# Altium schematic sheet Power_Board_DRV8323.SchDoc
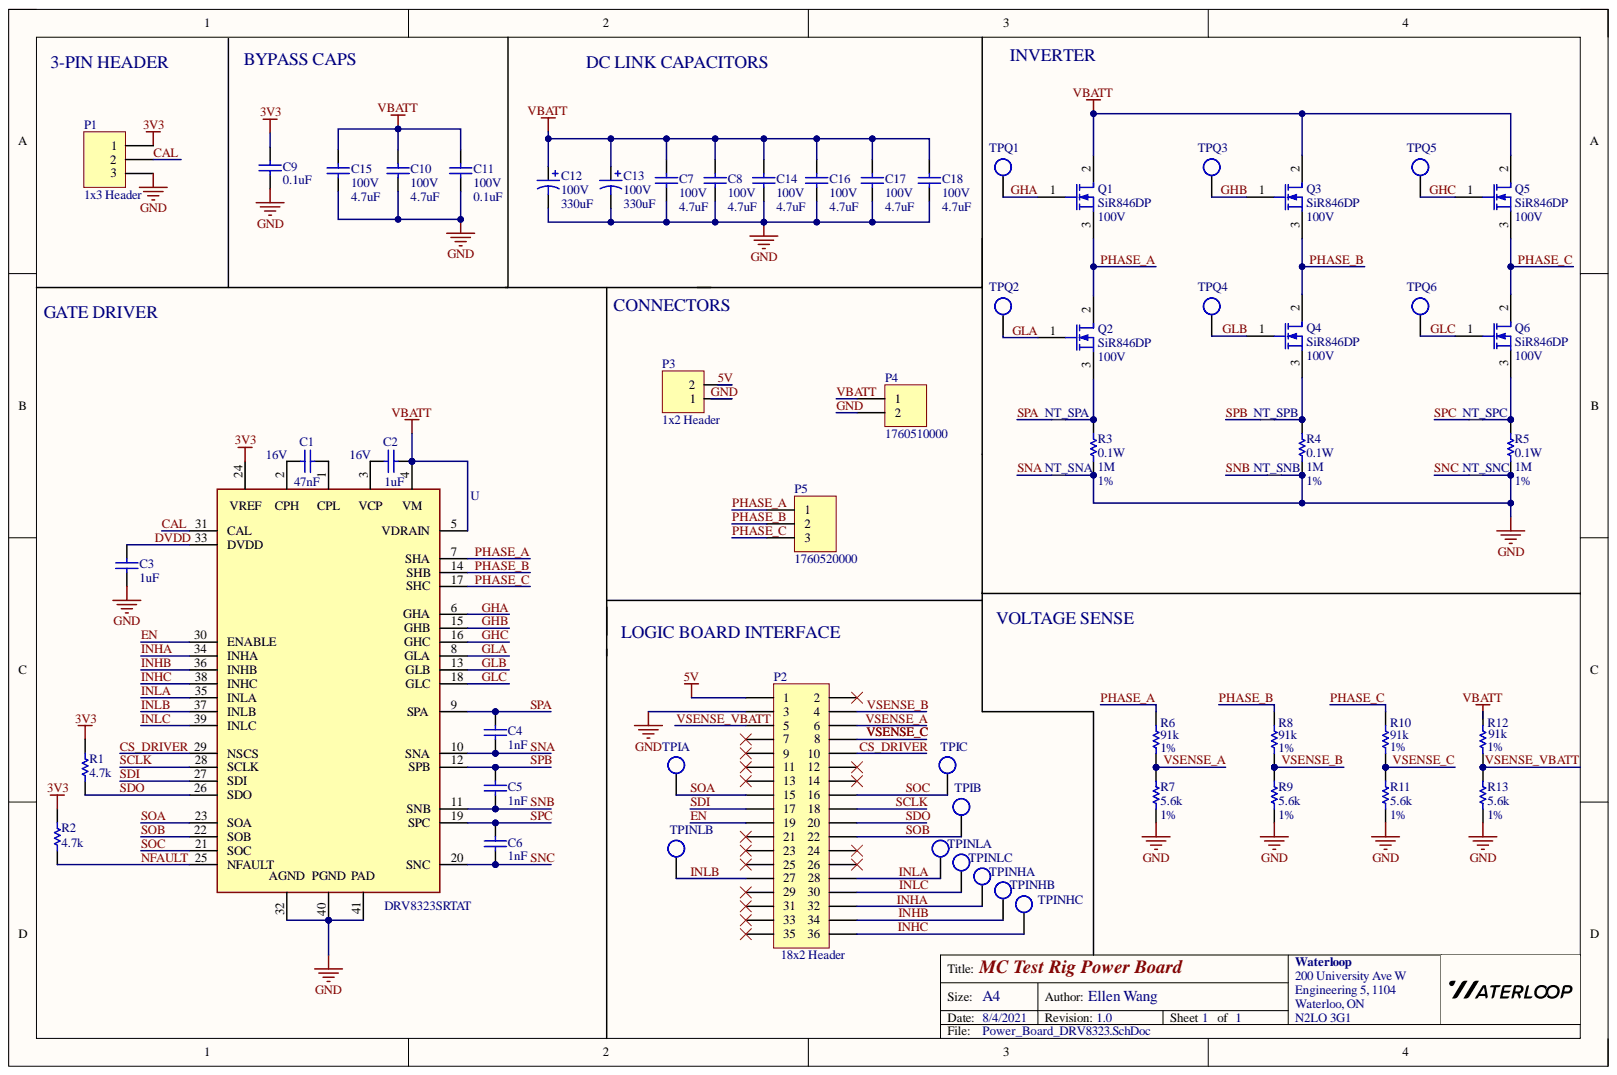
[diagram p0: Power_Board_DRV8323.SchDoc]

## Parts
C1  value 47nF  Rating: 16V  [0805 - Capacitor]  (hidden comment: Capacitor - Nonpolarized)
C2  value 1uF  Rating: 16V  [0805 - Capacitor]  (hidden comment: Capacitor - Nonpolarized)
C3  value 1uF  [0805 - Capacitor]  (hidden comment: Capacitor - Nonpolarized)
C4  value 1nF  [0805 - Capacitor]  (hidden comment: Capacitor - Nonpolarized)
C5  value 1nF  [0805 - Capacitor]  (hidden comment: Capacitor - Nonpolarized)
C6  value 1nF  [0805 - Capacitor]  (hidden comment: Capacitor - Nonpolarized)
C7  value 4.7uF  Rating: 100V  [1210 - Capacitor]  (hidden comment: Capacitor - Nonpolarized)
C8  value 4.7uF  Rating: 100V  [1210 - Capacitor]  (hidden comment: Capacitor - Nonpolarized)
C9  value 0.1uF  [0805 - Capacitor]  (hidden comment: Capacitor - Nonpolarized)
C10  value 4.7uF  Rating: 100V  [1210 - Capacitor]  (hidden comment: Capacitor - Nonpolarized)
C11  value 0.1uF  Rating: 100V  [0805 - Capacitor]  (hidden comment: Capacitor - Nonpolarized)
C12  value 330uF  Rating: 100V  [OCVZ0606 - CAPACITOR]  (hidden comment: Capacitor - Polarized)
C13  value 330uF  Rating: 100V  [OCVZ0606 - CAPACITOR]  (hidden comment: Capacitor - Polarized)
C14  value 4.7uF  Rating: 100V  [1210 - Capacitor]  (hidden comment: Capacitor - Nonpolarized)
C15  value 4.7uF  Rating: 100V  [1210 - Capacitor]  (hidden comment: Capacitor - Nonpolarized)
C16  value 4.7uF  Rating: 100V  [1210 - Capacitor]  (hidden comment: Capacitor - Nonpolarized)
C17  value 4.7uF  Rating: 100V  [1210 - Capacitor]  (hidden comment: Capacitor - Nonpolarized)
C18  value 4.7uF  Rating: 100V  [1210 - Capacitor]  (hidden comment: Capacitor - Nonpolarized)
NT_SNA  NetTie  [Net Tie]  (value not drawn)
NT_SNB  NetTie  [Net Tie]  (value not drawn)
NT_SNC  NetTie  [Net Tie]  (value not drawn)
NT_SPA  NetTie  [Net Tie]  (value not drawn)
NT_SPB  NetTie  [Net Tie]  (value not drawn)
NT_SPC  NetTie  [Net Tie]  (value not drawn)
P1  1x3 Header  [HDR1X3]
P2  Header 18X2  [HDR2X18]  (value not drawn)
P3  1x2 Header  [HDR1X2]
P4  1760510000  [1760510000]
P5  1760520000  [1760520000]
Q1  Rating: 100V  Supplier Part Number: SiR846DP  [PowerPAK® SO-8]  (hidden comment: MOSFET-N)
Q2  Rating: 100V  Supplier Part Number: SiR846DP  [PowerPAK® SO-8]  (hidden comment: MOSFET-N)
Q3  Rating: 100V  Supplier Part Number: SiR846DP  [PowerPAK® SO-8]  (hidden comment: MOSFET-N)
Q4  Rating: 100V  Supplier Part Number: SiR846DP  [PowerPAK® SO-8]  (hidden comment: MOSFET-N)
Q5  Rating: 100V  Supplier Part Number: SiR846DP  [PowerPAK® SO-8]  (hidden comment: MOSFET-N)
Q6  Rating: 100V  Supplier Part Number: SiR846DP  [PowerPAK® SO-8]  (hidden comment: MOSFET-N)
R1  value 4.7k  [0603 - Resistor]  (hidden comment: Resistor)
R2  value 4.7k  [0603 - Resistor]  (hidden comment: Resistor)
R3  value 1M  Rating: 0.1W  Tolerance: 1%  [0603 - Resistor]  (hidden comment: Resistor)
R4  value 1M  Rating: 0.1W  Tolerance: 1%  [0603 - Resistor]  (hidden comment: Resistor)
R5  value 1M  Rating: 0.1W  Tolerance: 1%  [0603 - Resistor]  (hidden comment: Resistor)
R6  value 91k  Tolerance: 1%  [0603 - Resistor]  (hidden comment: Resistor)
R7  value 5.6k  Tolerance: 1%  [0603 - Resistor]  (hidden comment: Resistor)
R8  value 91k  Tolerance: 1%  [0603 - Resistor]  (hidden comment: Resistor)
R9  value 5.6k  Tolerance: 1%  [0603 - Resistor]  (hidden comment: Resistor)
R10  value 91k  Tolerance: 1%  [0603 - Resistor]  (hidden comment: Resistor)
R11  value 5.6k  Tolerance: 1%  [0603 - Resistor]  (hidden comment: Resistor)
R12  value 91k  Tolerance: 1%  [0603 - Resistor]  (hidden comment: Resistor)
R13  value 5.6k  Tolerance: 1%  [0603 - Resistor]  (hidden comment: Resistor)
TPIA  Test Point  [Test Point]  (value not drawn)  (no BOM)
TPIB  Test Point  [Test Point]  (value not drawn)  (no BOM)
TPIC  Test Point  [Test Point]  (value not drawn)  (no BOM)
TPINHA  Test Point  [Test Point]  (value not drawn)  (no BOM)
TPINHB  Test Point  [Test Point]  (value not drawn)  (no BOM)
TPINHC  Test Point  [Test Point]  (value not drawn)  (no BOM)
TPINLA  Test Point  [Test Point]  (value not drawn)  (no BOM)
TPINLB  Test Point  [Test Point]  (value not drawn)  (no BOM)
TPINLC  Test Point  [Test Point]  (value not drawn)  (no BOM)
TPQ1  Test Point  [Test Point]  (value not drawn)  (no BOM)
TPQ2  Test Point  [Test Point]  (value not drawn)  (no BOM)
TPQ3  Test Point  [Test Point]  (value not drawn)  (no BOM)
TPQ4  Test Point  [Test Point]  (value not drawn)  (no BOM)
TPQ5  Test Point  [Test Point]  (value not drawn)  (no BOM)
TPQ6  Test Point  [Test Point]  (value not drawn)  (no BOM)
U  DRV8323SRTAT  [DRV8323SRTAT]

## Nets
3V3: C9.1 P1.1 R1.2 R2.2 U.24
5V: P2.1 P3.2
CAL: P1.2 U.31(CAL)
CS_DRIVER: P2.10 U.29(NSCS)
DVDD: C3.2 U.33(DVDD)
EN: P2.19 U.30(ENABLE)
GHA: Q1.1 TPQ1.1 U.6(GHA)
GHB: Q3.1 TPQ3.1 U.15(GHB)
GHC: Q5.1 TPQ5.1 U.16(GHC)
GLA: Q2.1 TPQ2.1 U.8(GLA)
GLB: Q4.1 TPQ4.1 U.13(GLB)
GLC: Q6.1 TPQ6.1 U.18(GLC)
GND: C3.1 C7.2 C8.2 C9.2 C10.2 C11.2 C12.2 C13.2 C14.2 C15.2 C16.2 C17.2 C18.2 NT_SNA.2 NT_SNB.2 NT_SNC.2 P1.3 P2.3 P3.1 P4.2 R3.1 R4.1 R5.1 R7.2 R9.2 R11.2 R13.2 U.32 U.40 U.41
INHA: P2.32 TPINHA.1 U.34(INHA)
INHB: P2.34 TPINHB.1 U.36(INHB)
INHC: P2.36 TPINHC.1 U.38(INHC)
INLA: P2.28 TPINLA.1 U.35(INLA)
INLB: P2.27 TPINLB.1 U.37(INLB)
INLC: P2.30 TPINLC.1 U.39(INLC)
NFAULT: R2.1 U.25(NFAULT)
PHASE_A: P5.1 Q1.3 Q2.2 R6.1 U.7(SHA)
PHASE_B: P5.2 Q3.3 Q4.2 R8.1 U.14(SHB)
PHASE_C: P5.3 Q5.3 Q6.2 R10.1 U.17(SHC)
SCLK: P2.18 U.28(SCLK)
SDI: P2.17 U.27(SDI)
SDO: P2.20 R1.1 U.26(SDO)
SNA: C4.1 NT_SNA.1 U.10(SNA)
SNB: C5.1 NT_SNB.1 U.11(SNB)
SNC: C6.1 NT_SNC.1 U.20(SNC)
SOA: P2.15 TPIA.1 U.23(SOA)
SOB: P2.22 TPIB.1 U.22(SOB)
SOC: P2.16 TPIC.1 U.21(SOC)
SPA: C4.2 NT_SPA.1 U.9(SPA)
SPB: C5.2 NT_SPB.1 U.12(SPB)
SPC: C6.2 NT_SPC.1 U.19(SPC)
VBATT: C2.2 C7.1 C8.2 C10.2 C11.2 C12.1 C13.1 C14.2 C15.2 C16.2 C17.2 C18.2 P4.1 Q1.2 Q3.2 Q5.2 R12.1 U.4(VM) U.5(VDRAIN)
VSENSE_A: P2.6 R6.2 R7.1
VSENSE_B: P2.4 R8.2 R9.1
VSENSE_C: P2.8 R10.2 R11.1
VSENSE_VBATT: P2.5 R12.2 R13.1
NetC1_1: C1.1 U.2
NetC1_2: C1.2 U.1
NetC2_1: C2.1 U.3
NetNT_SPA_2: NT_SPA.2 Q2.3 R3.2
NetNT_SPB_2: NT_SPB.2 Q4.3 R4.2
NetNT_SPC_2: NT_SPC.2 Q6.3 R5.2

Not connected: P2 2 7 9 11 12 13 14 21 23 24 25 26 29 31 33 35

## Library data of the parts
C1 = Capacitor - Nonpolarized: Nonpolarized Capacitor; Manufacturer: YAGEO; Manufacturer Part Number: CC0805KKX7R0BB473; Value: 47nF; Component Kind: Standard; Library Name: General.SchLib; Signal Integrity: Cap; Simulation: CAP; Supplier: Digikey; Supplier Part Number: 311-1876-1-ND
C2 = Capacitor - Nonpolarized: Nonpolarized Capacitor; Manufacturer: YAGEO; Manufacturer Part Number: CC0805KKX7R7BB105; Value: 1uF; Component Kind: Standard; Library Name: General.SchLib; Signal Integrity: Cap; Simulation: CAP; Supplier: Digikey; Supplier Part Number: 311-1365-1-ND
C3 = Capacitor - Nonpolarized: Nonpolarized Capacitor; Manufacturer: YAGEO; Manufacturer Part Number: CC0805KKX7R7BB105; Rating: 16V; Component Kind: Standard; Library Name: General.SchLib; Signal Integrity: Cap; Simulation: CAP; Supplier: Digikey; Supplier Part Number: 311-1365-1-ND
C4-C6 = Capacitor - Nonpolarized: Nonpolarized Capacitor; Manufacturer: YAGEO; Manufacturer Part Number: CC0805KRX7R0BB102; Rating: 100V; Component Kind: Standard; Library Name: General.SchLib; Signal Integrity: Cap; Simulation: CAP; Supplier: Digikey; Supplier Part Number: 311-1472-1-ND
C7 C8 C10 C14-C18 = Capacitor - Nonpolarized: Nonpolarized Capacitor; Manufacturer: Taiyo Yuden; Manufacturer Part Number: HMK325C7475KN-TE; Component Kind: Standard; Library Name: General.SchLib; Signal Integrity: Cap; Simulation: CAP; Supplier: Digikey; Supplier Part Number: 587-5038-1-ND
C9 = Capacitor - Nonpolarized: Nonpolarized Capacitor; Manufacturer: Samsung Electro-Mechanics; Manufacturer Part Number: CL21B104KCFWPNE; Rating: 100V; Component Kind: Standard; Library Name: General.SchLib; Signal Integrity: Cap; Simulation: CAP; Supplier: Digikey; Supplier Part Number: 1276-6733-1-ND
C11 = Capacitor - Nonpolarized: Nonpolarized Capacitor; Manufacturer: Samsung Electro-Mechanics; Manufacturer Part Number: CL21B104KCFWPNE; Component Kind: Standard; Library Name: General.SchLib; Signal Integrity: Cap; Simulation: CAP; Supplier: Digikey; Supplier Part Number: 1276-6733-1-ND
C12 C13 = Capacitor - Polarized: Polarized Capacitor; Component Kind: Standard; Library Name: General.SchLib; Signal Integrity: Cap Pol; Simulation: CAP
NT_SNA NT_SNB NT_SNC NT_SPA NT_SPB NT_SPC = Net Tie: Component Kind: Net Tie; Library Name: General.SchLib
P1 = 1x3 Header: 1x3 Pin Header; Component Kind: Standard; Library Name: GeneralConnectors.SchLib; Signal Integrity: Connector
P2 = Header 18X2: Header, 18-Pin, Dual row; Component Kind: Standard; LatestRevisionDate: 17-Jul-2002; LatestRevisionNote: Re-released for DXP Platform.; Library Name: Miscellaneous Connectors.IntLib; Signal Integrity: Connector; Publisher: Altium Limited
P3 = 1x2 Header: 1x2 Pin Header; Component Kind: Standard; Library Name: GeneralConnectors.SchLib; Signal Integrity: Connector
P4 = 1760510000: 1x2 Screw Terminal Block; Manufacturer: Weidmüller; Component Kind: Standard; ComponentLink1Description: Datasheet; ComponentLink1URL: https://media.digikey.com/pdf/Data%20Sheets/Weidmuller%20PDFs/1760510000.pdf; Library Name: GeneralConnectors.SchLib; Manufacturer Part Number: 1760510000; Supplier: Digikey; Supplier Part Number: 281-1882-ND
P5 = 1760520000: 1x3 Screw Terminal Block; Manufacturer: Weidmüller; Component Kind: Standard; ComponentLink1Description: Datasheet; ComponentLink1URL: https://media.digikey.com/pdf/Data%20Sheets/Weidmuller%20PDFs/1760520000.pdf; Library Name: GeneralConnectors.SchLib; Manufacturer Part Number: 1760520000; Supplier: Digikey; Supplier Part Number: 281-1883-ND
Q1-Q6 = MOSFET-N: N-Channel MOSFET; Component Kind: Standard; Library Name: General.SchLib; Simulation: NMOS
R1 R2 = Resistor: Manufacturer: YAGEO; Manufacturer Part Number: RC0603FR-074K7L; Component Kind: Standard; Description: Resistor; Library Name: General.SchLib; Signal Integrity: Res; Simulation: RESISTOR; Supplier: Digikey; Supplier Part Number: 311-4.70KHRCT-ND
R3-R5 = Resistor: Manufacturer: YAGEO; Manufacturer Part Number: RC0603FR-071ML; Component Kind: Standard; Description: Resistor; Library Name: General.SchLib; Signal Integrity: Res; Simulation: RESISTOR; Supplier: 311-1.00MHRCT-ND; Supplier Part Number: HCS2512FT1L00CT-ND
R6 R8 R10 R12 = Resistor: Manufacturer: YAGEO; Manufacturer Part Number: RC0603FR-0791KL; Component Kind: Standard; Description: Resistor; Library Name: General.SchLib; Signal Integrity: Res; Simulation: RESISTOR; Supplier: Digikey; Supplier Part Number: 311-91.0KHRCT-ND
R7 R9 R11 R13 = Resistor: Manufacturer: YAGEO; Manufacturer Part Number: RC0603FR-075K6L; Component Kind: Standard; Description: Resistor; Library Name: General.SchLib; Signal Integrity: Res; Simulation: RESISTOR; Supplier: Digikey; Supplier Part Number: 311-5.60KHRCT-ND
U = DRV8323SRTAT: Multiphase Motor Driver Power MOSFET SPI; Manufacturer: Texas Instruments; Component Kind: Standard; ComponentLink1Description: Datasheet; ComponentLink1URL: https://www.ti.com/lit/ds/symlink/drv8323.pdf?HQS=dis-dk-null-digikeymode-dsf-pf-null-wwe&ts=1623708117864; Library Name: MC.SchLib; Manufacturer Part Number: DRV8323SRTAT; Supplier: Digikey; Supplier Part Number: 296-47095-1-ND
TPIA TPIB TPIC TPINHA TPINHB TPINHC TPINLA TPINLB TPINLC TPQ1-TPQ6 = Test Point: Description: Test Point; Library Name: General.SchLib
